# Altium schematic sheet [05]Motor.SchDoc([05]Motor)
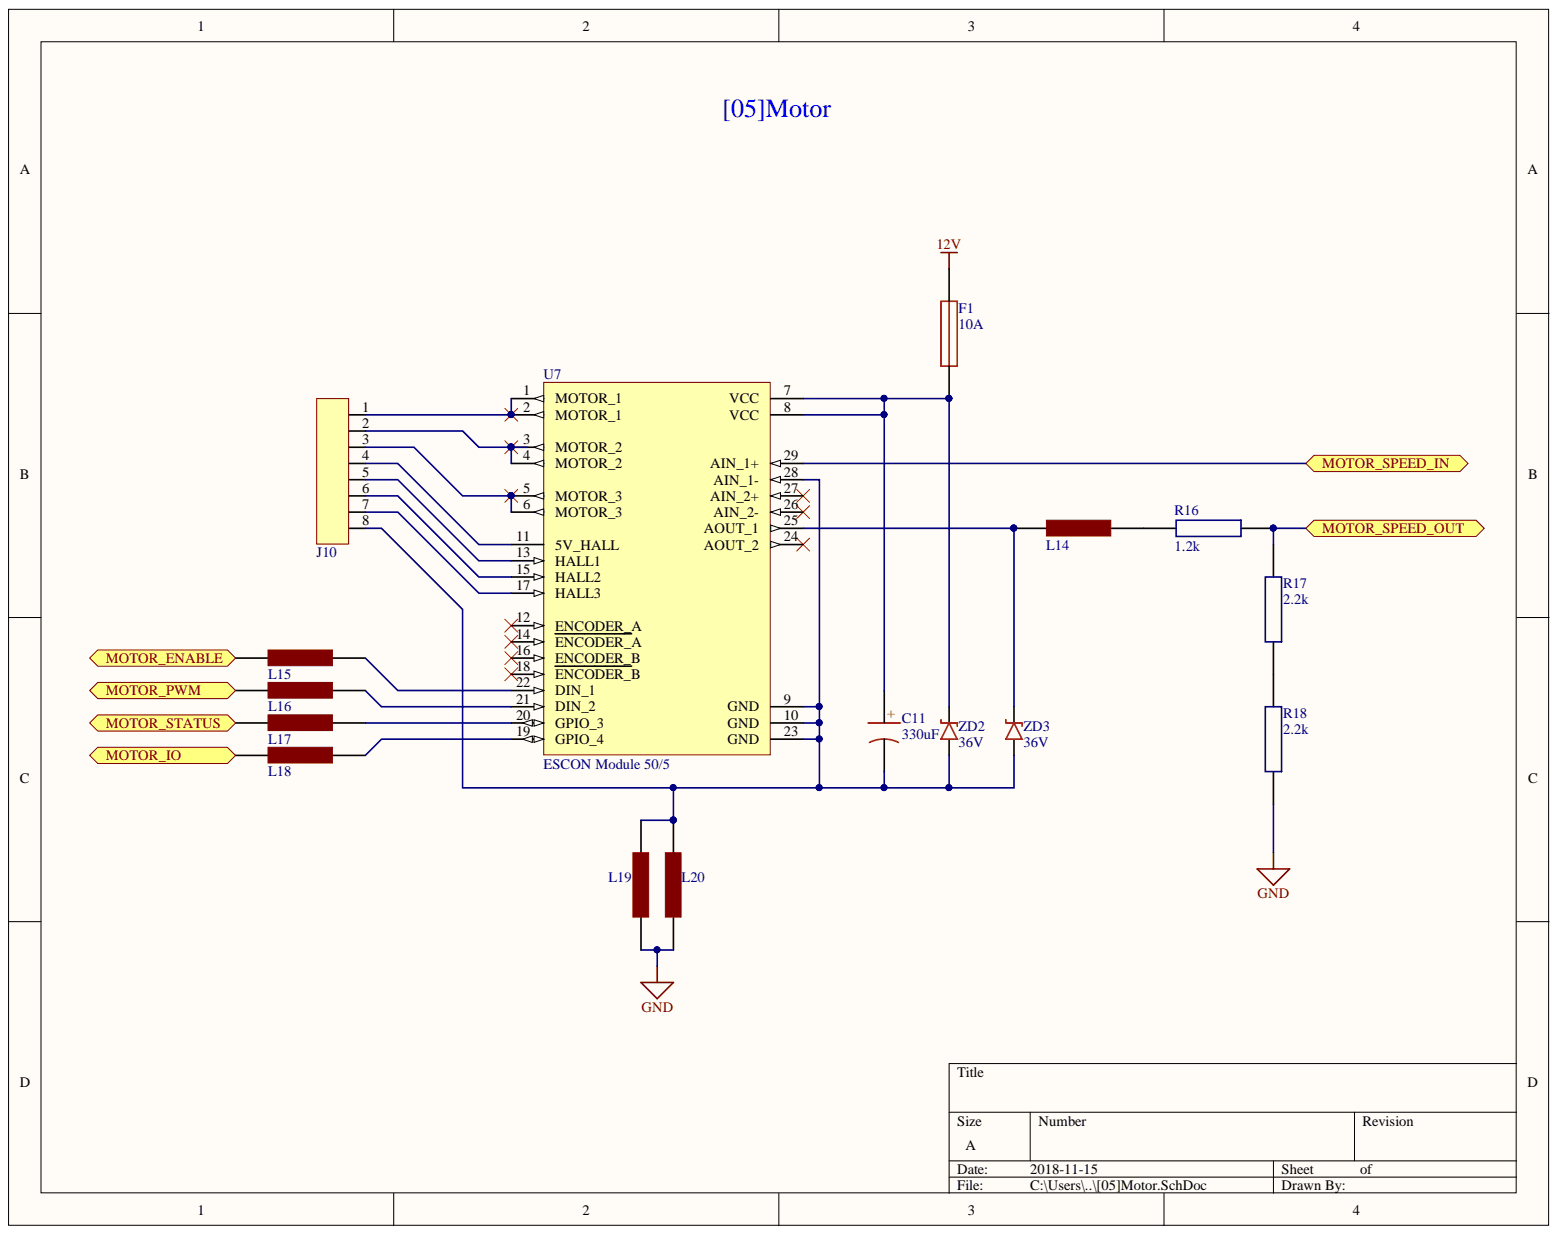
[diagram p0: [05]Motor.SchDoc]

## Parts
C11  330uF  [CAPAE137130X140M]
F1  10A  [0157004.DR]
J10  1771156  [1771156]  (value not drawn)
L14  MH2029-300Y  [INDC2012X11N]  (value not drawn)
L15  MH2029-300Y  [INDC2012X11N]  (value not drawn)
L16  MH2029-300Y  [INDC2012X11N]  (value not drawn)
L17  MH2029-300Y  [INDC2012X11N]  (value not drawn)
L18  MH2029-300Y  [INDC2012X11N]  (value not drawn)
L19  MH2029-300Y  [INDC2012X11N]  (value not drawn)
L20  MH2029-300Y  [INDC2012X11N]  (value not drawn)
R16  1.2k  [RESC2012X07N_SAMSUNG]
R17  2.2k  [RESC2012X07N_SAMSUNG]
R18  2.2k  [RESC2012X07N_SAMSUNG]
U7  ESCON Module 50/5  [ESCON Module 50/5 SMD]
ZD2  36V  [SOT95P237X111-3N]
ZD3  36V  [SOT95P237X111-3N]

## Ports
MOTOR_ENABLE, MOTOR_IO, MOTOR_PWM, MOTOR_SPEED_IN, MOTOR_SPEED_OUT, MOTOR_STATUS

## Nets
12V: F1.2
GND: L19.2 L20.1 R18.1
MOTOR_ENABLE: L15.1 ; port MOTOR_ENABLE
MOTOR_IO: L18.1 ; port MOTOR_IO
MOTOR_PWM: L16.1 ; port MOTOR_PWM
MOTOR_SPEED_IN: U7.29(AIN_1+) ; port MOTOR_SPEED_IN
MOTOR_SPEED_OUT: R16.1 R17.2 ; port MOTOR_SPEED_OUT
MOTOR_STATUS: L17.1 ; port MOTOR_STATUS
NetC11_1: C11.1 F1.1 U7.7(VCC) U7.8(VCC) ZD2.K
NetC11_2: C11.2 J10.8 L19.1 L20.2 U7.9(GND) U7.10(GND) U7.23(GND) U7.28(AIN_1-) ZD2.A ZD3.A
NetJ10_1: J10.1 U7.1(MOTOR_1) U7.2(MOTOR_1)
NetJ10_2: J10.2 U7.3(MOTOR_2) U7.4(MOTOR_2)
NetJ10_3: J10.3 U7.5(MOTOR_3) U7.6(MOTOR_3)
NetJ10_4: J10.4 U7.11(5V_HALL)
NetJ10_5: J10.5 U7.13(HALL1)
NetJ10_6: J10.6 U7.15(HALL2)
NetJ10_7: J10.7 U7.17(HALL3)
NetL14_1: L14.1 U7.25(AOUT_1) ZD3.K
NetL14_2: L14.2 R16.2
NetL15_2: L15.2 U7.22(DIN_1)
NetL16_2: L16.2 U7.21(DIN_2)
NetL17_2: L17.2 U7.20(GPIO_3)
NetL18_2: L18.2 U7.19(GPIO_4)
NetR17_1: R17.1 R18.2

Not connected: U7 12(ENCODER_A) 14(ENCODER_A) 16(ENCODER_B) 18(ENCODER_B) 24(AOUT_2) 26(AIN_2-) 27(AIN_2+)

## Library data of the parts
C11 = EMVH350ARA331MKE0S: CAP ALUM 330UF 20% 35V SMD; Supplier Part Number 1: 565-2316-1-ND; Supplier 1: Digi-Key; Component Kind: Standard; Library Name: AMMDK Parts.SchLib
F1 = 0157010.DR: FUSE BRD MNT 10A 125VAC/VDC SMD; Manufacturer: Littelfuse Inc.; Response Time: Fast; Fuse Type: Board Mount (Cartridge Style Excluded); Breaking Capacity @ Rated Voltage: 35A AC, 50A DC; Approvals: cULus, PSE; Supplier Part Number 1: F2973CT-ND; Supplier 1: Digi-Key; Stock 1: 6229; Size / Dimension: 0.256" L x 0.146" W x 0.154" H (6.50mm x 3.70mm x 3.90mm); RoHS: RoHS Compliant; Pricing 1: 1=2.73, 5=2.6, 10=2.47, 50=1.9936, 100=1.6903, 250=1.64692, 500=1.38688 (USD); Part Status: Active; Packaging: Cut Tape (CT); Package / Case: 2-SMD, Square End Block with Clip; Operating Temperature: -55°C ~ 125°C; Mounting Type: Holder, Surface Mount; Manufacturer Part Number: 0157010.DR; ComponentLink1URL: http://www.littelfuse.com/products/fuse-blocks-fuseholders-and-fuse-accessories/fuse-clips/~/media/electronics/datasheets/fuses/littelfuse_fuse_157_datasheet.pdf.pdf; ComponentLink1Description: http://www.littelfuse.com/products/fuse-blocks-fuseholders-and-fuse-accessories/fuse-clips/~/media/electronics/datasheets/fuses/littelfuse_fuse_157_datasheet.pdf.pdf; Component Kind: Standard; Color: -; Category: Circuit Protection; Library Name: AMMDK Parts.SchLib
J10 = 1771156: CONN TERM BLK 8POS 2.5MM SMD; Manufacturer: Phoenix Contact; Wire Termination: Screwless - Spring Cage, Tension Clamp; Wire Gauge: 20-26 AWG; Positions Per Level: 8; Pitch: 0.098" (2.50mm); Mating Orientation: Vertical with Board; Torque - Screw: -; Supplier Part Number 1: 277-2078-1-ND; Supplier 1: Digi-Key; Stock 1: 2856; Screw Thread: -; Screw Material - Plating: -; RoHS: RoHS Compliant; Pricing 1: 1=1.39, 10=1.334, 50=1.276, 100=1.2412 (USD); Part Status: Active; Packaging: Cut Tape (CT); Operating Temperature: -; Mounting Type: Surface Mount; Manufacturer Part Number: 1771156; Housing Material: Liquid Crystal Polymer (LCP); Features: Solder Retention; Contact Material - Plating: -; ComponentLink1URL: https://media.digikey.com/pdf/Data%20Sheets/Phoenix%20Contact%20PDFs/1771156.pdf; ComponentLink1Description: https://media.digikey.com/pdf/Data%20Sheets/Phoenix%20Contact%20PDFs/1771156.pdf; Component Kind: Standard; Color: Black; Clamp Material - Plating: -; Category: Connectors, Interconnects; Library Name: AMMDK Parts.SchLib
L14-L20 = MH2029-300Y: EMI Filter Beads, Chips & Arrays Impedance 30ohms IDC 3.0; Manufacturer: Bourns; Type: High Current Chip Ferrite Bead; Tolerance: 25 %; Test Frequency: 100 MHz; Number of Lines: 1; Maximum DC Resistance: 25 mOhms; Maximum DC Current: 3 A; Impedance @ Frequency: 30 Ohm @ 100MHz; Filter Type: Power, Signal Line; DC Resistance (DCR) (Max): 25 mOhm; Current Rating (Max): 3A; Width: 1.2 mm; Termination Style: SMD/SMT; Supplier Part Number 1: MH2029-300YCT-ND; Supplier 1: Digi-Key; Stock 1: 62738; Standard Pack Qty: 4000; Size / Dimension: 0.079" L x 0.047" W (2.00mm x 1.20mm); RoHS - Mouser: Y; RoHS: RoHS Compliant; Reeling: True; Ratings: -; Product Category: SMD Ferrite Bead; Product: Ferrite Chip Beads; Pricing 1: 1=0.1, 10=0.062, 25=0.0568, 50=0.051, 100=0.0375, 250=0.02724, 500=0.02498, 1000=0.02157 (USD); Packaging: Reel; Package / Case: 0805 (2012 metric); Operating Temperature Range: - 55 C to + 125 C; Operating Temperature: -55°C ~ 125°C; Mounting Type: Surface Mount; Minimum Order Quantity: 1; Manufacturer Part Number: MH2029-300Y; Length: 2 mm; Impedance: 30 Ohms; Height (Max): 0.043" (1.10mm); Height: 0.9 mm; ComponentLink2URL: http://www.bourns.com/docs/Product-Datasheets/mh.pdf; ComponentLink2Description: http://www.bourns.com/docs/Product-Datasheets/mh.pdf; ComponentLink1URL: http://www.mouser.com/ds/2/54/h-777565.pdf; ComponentLink1Description: http://www.mouser.com/ds/2/54/h-777565.pdf; Component Kind: Standard; Category: EMI Filter Beads, Chips & Arrays; Capacitance: -; Brand: Bourns; Library Name: AMMDK Parts.SchLib
R16 = RC2012F122CS: RES SMD 1.2K OHM 1% 1/8W 0805; Manufacturer Part Number: RC2012F103CS; Manufacturer: Samsung Electro-Mechanics America, Inc.; Temperature Coefficient: ±100ppm/°C; Resistance (Ohms): 10k; Power (Watts): 0.125W, 1/8W; Tolerance: ±1%; Supplier Part Number 1: 1276-5275-1-ND; Supplier Device Package: 0805 (2012 Metric); Supplier 1: Digi-Key; Size / Dimension: 0.079" L x 0.049" W (2.00mm x 1.25mm); RoHS: RoHS Compliant; Packaging: Cut Tape (CT); Package / Case: 0805 (2012 Metric); Operating Temperature: -55°C ~ 155°C; Number of Terminations: 2; Height: 0.024" (0.60mm); Features: Moisture Resistant; Composition: Thick Film; ComponentLink1URL: http://media.digikey.com/pdf/Data%20Sheets/Samsung%20PDFs/RC_Series_ds.pdf; ComponentLink1Description: http://media.digikey.com/pdf/Data%20Sheets/Samsung%20PDFs/RC_Series_ds.pdf; Component Kind: Standard; Category: Resistors; Library Name: AMMDK Parts.SchLib
R17 R18 = RC2012F222CS: RES SMD 2.2K OHM 1% 1/8W 0805; Manufacturer Part Number: RC2012F103CS; Manufacturer: Samsung Electro-Mechanics America, Inc.; Temperature Coefficient: ±100ppm/°C; Resistance (Ohms): 10k; Power (Watts): 0.125W, 1/8W; Tolerance: ±1%; Supplier Part Number 1: 1276-5290-1-ND; Supplier Device Package: 0805 (2012 Metric); Supplier 1: Digi-Key; Size / Dimension: 0.079" L x 0.049" W (2.00mm x 1.25mm); RoHS: RoHS Compliant; Packaging: Cut Tape (CT); Package / Case: 0805 (2012 Metric); Operating Temperature: -55°C ~ 155°C; Number of Terminations: 2; Height: 0.024" (0.60mm); Features: Moisture Resistant; Composition: Thick Film; ComponentLink1URL: http://media.digikey.com/pdf/Data%20Sheets/Samsung%20PDFs/RC_Series_ds.pdf; ComponentLink1Description: http://media.digikey.com/pdf/Data%20Sheets/Samsung%20PDFs/RC_Series_ds.pdf; Component Kind: Standard; Category: Resistors; Library Name: AMMDK Parts.SchLib
U7 = ESCON Module 50/5: 4-Q servo controller for DC/EC motors, 2/6 A, 10-50 VDC; Supplier Part Number 2: SAM1146-09-ND; Supplier Part Number 1: SAM1152-11-ND; Supplier 2: Digi-Key; Supplier 1: Digi-Key; Component Kind: Standard; Library Name: AMMDK Parts.SchLib
ZD2 ZD3 = BZX84C36LT1G: Zener Diode 36V 225mW ±6%; Supplier Part Number 1: BZX84C36LT1GOSCT-ND; Supplier 1: Digi-Key; Component Kind: Standard; Library Name: AMMDK Parts.SchLib
